# Altium schematic sheet D5200_V002_1_20180610.SchDoc(D5200_V002_1_20180610)
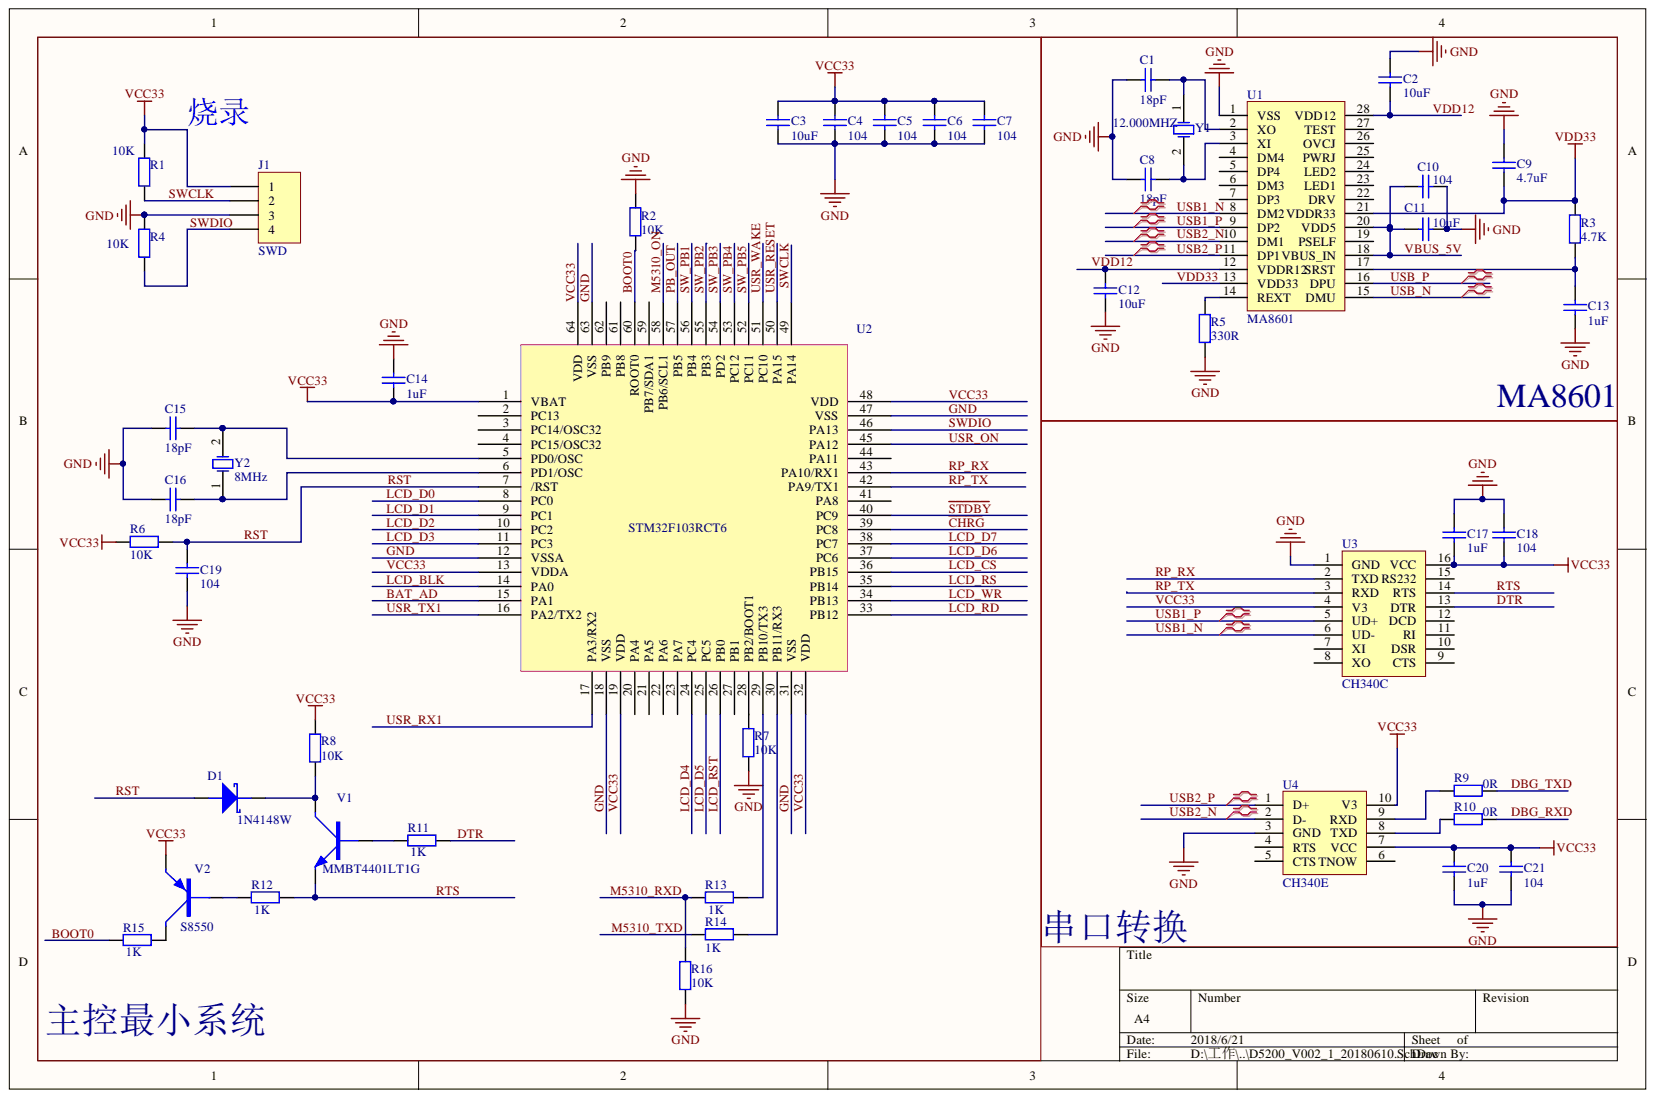
[diagram p0: D5200_V002_1_20180610.SchDoc]

## Parts
C1  18pF  [0402C]
C2  10uF  [0402C]
C3  10uF  [0402C]
C4  104  [0402C]
C5  104  [0402C]
C6  104  [0402C]
C7  104  [0402C]
C8  18pF  [0402C]
C9  4.7uF  [0402C]
C10  104  [0402C]
C11  10uF  [0402C]
C12  10uF  [0402C]
C13  1uF  [0402C]
C14  1uF  [0402C]
C15  18pF  [0402C]
C16  18pF  [0402C]
C17  1uF  [0402C]
C18  104  [0402C]
C19  104  [0402C]
C20  1uF  [0402C]
C21  104  [0402C]
D1  1N4148W  [LC-SOD-123]
J1  SWD  [HDR1X4]
R1  10K  [0402R]
R2  10K  [0402R]
R3  4.7K  [0402R]
R4  10K  [0402R]
R5  330R  [0402R]
R6  10K  [0402R]
R7  10K  [0402R]
R8  10K  [0402R]
R9  0R  [0402R]
R10  0R  [0402R]
R11  1K  [0402R]
R12  1K  [0402R]
R13  1K  [0402R]
R14  1K  [0402R]
R15  1K  [0402R]
R16  10K  [0402R]
U1  MA8601  [SSOP28_MA8601]
U2  STM32F103RCT6  [STM32F103RCT6]
U3  CH340C  [SOP-16]
U4  CH340E  [MSOP10]
V1  MMBT4401LT1G  [SOT-23 - MMBT4401LT1]
V2  S8550  [SOT-23]
Y1  12.000MHZ  [OSC_SMD_5*3.2mm]
Y2  8MHz  [OSC_SMD_5*3.2mm]

## Nets
BAT_AD: U2.15(PA1)
BOOT0: R2.1 R15.1 U2.60(ROOT0)
~{CHRG}: U2.39(PC8)
DBG_RXD: R10.1
DBG_TXD: R9.1
DTR: R11.2 U3.13(DTR)
GND: C1.1 C2.2 C3.2 C4.2 C5.2 C6.2 C7.2 C8.1 C9.2 C10.2 C11.2 C12.1 C13.2 C14.2 C15.1 C16.1 C17.1 C18.1 C19.1 C20.2 C21.2 J1.3 R2.2 R4.2 R5.2 R7.1 R16.1 U1.1(VSS) U2.12(VSSA) U2.18(VSS) U2.31(VSS) U2.47(VSS) U2.63(VSS) U3.1(GND) U4.3(GND)
LCD_BLK: U2.14(PA0)
LCD_CS: U2.36(PB15)
LCD_D0: U2.8(PC0)
LCD_D1: U2.9(PC1)
LCD_D2: U2.10(PC2)
LCD_D3: U2.11(PC3)
LCD_D4: U2.24(PC4)
LCD_D5: U2.25(PC5)
LCD_D6: U2.37(PC6)
LCD_D7: U2.38(PC7)
LCD_RD: U2.33(PB12)
LCD_RS: U2.35(PB14)
LCD_RST: U2.26(PB0)
LCD_WR: U2.34(PB13)
M5310_ON: U2.58(PB6/SCL1)
M5310_RXD: R13.1 R16.2
M5310_TXD: R14.2
PB_OUT: U2.57(PB5)
RP_RX: U2.43(PA10/RX1) U3.2(TXD)
RP_TX: U2.42(PA9/TX1) U3.3(RXD)
RST: C19.2 D1.A R6.1 U2.7(/RST)
RTS: R12.2 U3.14(RTS) V1.E
~{STDBY}: U2.40(PC9)
SW_PB1: U2.56(PB4)
SW_PB2: U2.55(PB3)
SW_PB3: U2.54(PD2)
SW_PB4: U2.53(PC12)
SW_PB5: U2.52(PC11)
SWCLK: J1.2 R1.1 U2.49(PA14)
SWDIO: J1.4 R4.1 U2.46(PA13)
USB1_N: U1.8(DM2) U3.6(UD-)
USB1_P: U1.9(DP2) U3.5(UD+)
USB2_N: U1.10(DM1) U4.2(D-)
USB2_P: U1.11(DP1) U4.1(D+)
USB_N: U1.15(DMU)
USB_P: U1.16(DPU)
USR_ON: U2.45(PA12)
USR_RESET: U2.50(PA15)
USR_RX1: U2.17(PA3/RX2)
USR_TX1: U2.16(PA2/TX2)
USR_WAKE: U2.51(PC10)
VBUS_5V: C10.1 C11.1 U1.18(VBUS_IN) U1.20(VDD5)
VCC33: C3.1 C4.1 C5.1 C6.1 C7.1 C14.1 C17.2 C18.2 C20.1 C21.1 J1.1 R1.2 R6.2 R8.2 U2.1(VBAT) U2.13(VDDA) U2.19(VDD) U2.32(VDD) U2.48(VDD) U2.64(VDD) U3.4(V3) U3.16(VCC) U4.7(VCC) U4.10(V3) V2.E
VDD12: C2.1 C12.2 U1.12(VDDR12) U1.28(VDD12)
VDD33: C9.1 R3.1 U1.13(VDD33) U1.21(VDDR33)
NetC1_2: C1.2 U1.2(XO) Y1.1
NetC8_2: C8.2 U1.3(XI) Y1.2
NetC13_1: C13.1 R3.2 U1.17(SRST)
NetC15_2: C15.2 U2.5(PD0/OSC) Y2.2
NetC16_2: C16.2 U2.6(PD1/OSC) Y2.1
NetD1_K: D1.K R8.1 V1.C
NetR5_1: R5.1 U1.14(REXT)
NetR7_2: R7.2 U2.28(PB2/BOOT1)
NetR9_2: R9.2 U4.9(RXD)
NetR10_2: R10.2 U4.8(TXD)
NetR11_1: R11.1 V1.B
NetR12_1: R12.1 V2.B
NetR13_2: R13.2 U2.29(PB10/TX3)
NetR14_1: R14.1 U2.30(PB11/RX3)
NetR15_2: R15.2 V2.C

Not connected: U1 4(DM4) 5(DP4) 6(DM3) 7(DP3) 19(PSELF) 22(DRV) 23(LED1) 24(LED2) 25(PWRJ) 26(OVCJ) 27(TEST); U2 2(PC13) 3(PC14/OSC32) 4(PC15/OSC32) 20(PA4) 21(PA5) 22(PA6) 23(PA7) 27(PB1) 41(PA8) 44(PA11) 59(PB7/SDA1) 61(PB8) 62(PB9); U3 7(XI) 8(XO) 9(CTS) 10(DSR) 11(RI) 12(DCD) 15(RS232); U4 4(RTS) 5(CTS) 6(TNOW)

## Library data of the parts
C1 C2 C8-C13 C17 C18 C20 C21 = Cap: Capacitor; Component Kind: Standard; Library Name: Miscellaneous Devices.IntLib
  C1 C8: Value: 18pF
  C2 C11 C12: Value: 10uF
  C9: Value: 4.7uF
  C10 C18 C21: Value: 104
  C13 C17 C20: Value: 1uF
C3-C7 C14-C16 C19 = 0201: Component Kind: Standard; Library Name: Capacitor.IntLib
  C3: Value: 10uF
  C4-C7 C19: Value: 104
  C14: Value: 1uF
  C15 C16: Value: 18pF
D1 = DIODE SCHOTTKY: 肖特基二极管; Component Kind: Standard; Library Name: 参考设计库.SchLib
J1 = 06030014: 插针、方脚、4PIN、单排、直式、2.54、5.84、2mmPCB、3A、公; Component Kind: Standard; Library Name: Connector.IntLib; Value: SWD
R1 R2 R4 R6 R7 R14 R16 = 0101: Component Kind: Standard; Library Name: Resistor.IntLib
  R2 R7 R16: Value: 10K
  R14: Value: 1K
R3 R5 R9 R10 = Res2: Resistor; Component Kind: Standard; Library Name: Miscellaneous Devices.IntLib
  R3: Value: 4.7K
  R5: Value: 330R
  R9 R10: Value: 0R
R8 R11-R13 R15 = 0101: Value: 0603; Component Kind: Standard; Library Name: Resistor.IntLib
U2 = STM32F103RBT6: Component Kind: Standard; Description: STM32F103RCT6; Library Name: GPRS.SchLib
U3 = CH340G: Component Kind: Standard; Library Name: 参考设计库.SchLib
V1 = NPN: NPN三极管; Component Kind: Standard; Library Name: 参考设计库.SchLib
V2 = PNP: PNP三极管; Component Kind: Standard; Library Name: 参考设计库.SchLib
Y1 Y2 = XTAL: Crystal Oscillator; Component Kind: Standard; LatestRevisionDate: 17-Jul-2002; LatestRevisionNote: Re-released for DXP Platform.; Library Name: Miscellaneous Devices.IntLib; PackageDescription: Crystal, Thru-Hole; 2 Leads; Body 3.1 x 8.2 mm (Dia.xH); PackageReference: R38; Published: 8-Jun-2000; Publisher: Altium Limited
U1 = MA8601: Component Kind: Standard; Library Name: 参考设计库.SchLib
U4 = CH340E: Component Kind: Standard; Library Name: 参考设计库.SchLib
